# Altium schematic sheet MCP73831_BMS.SchDoc
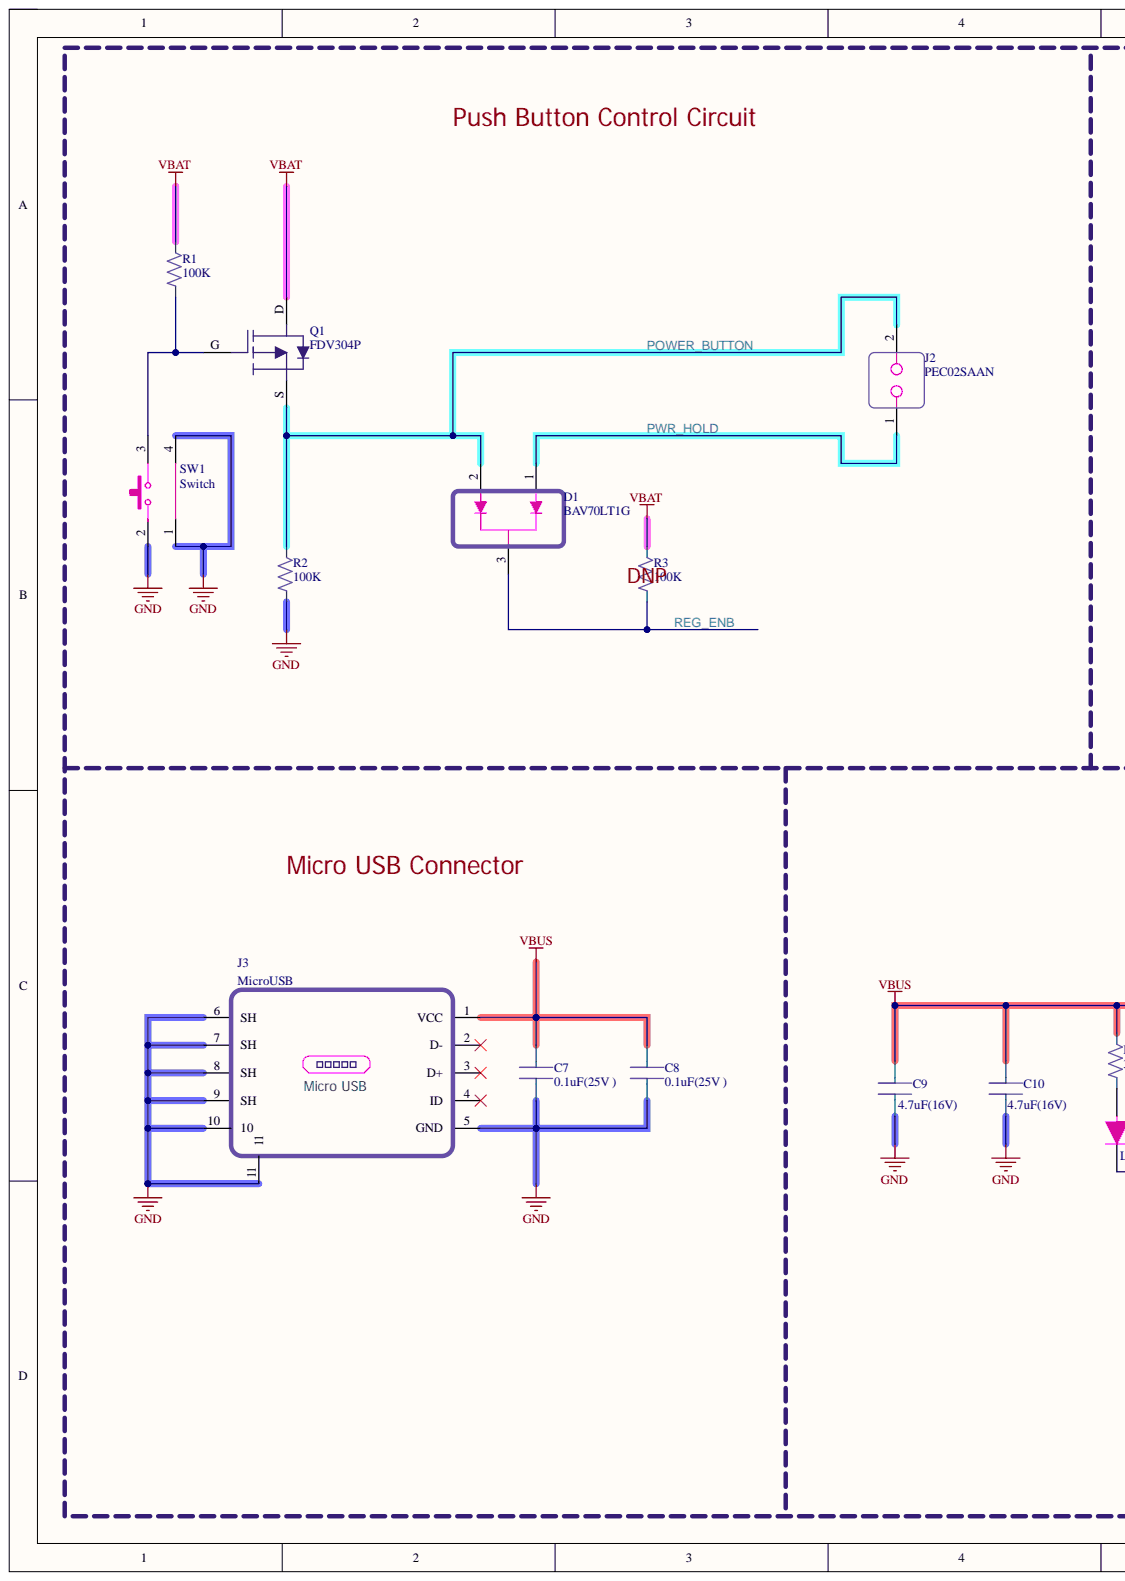
[diagram p0: MCP73831_BMS.SchDoc, left]
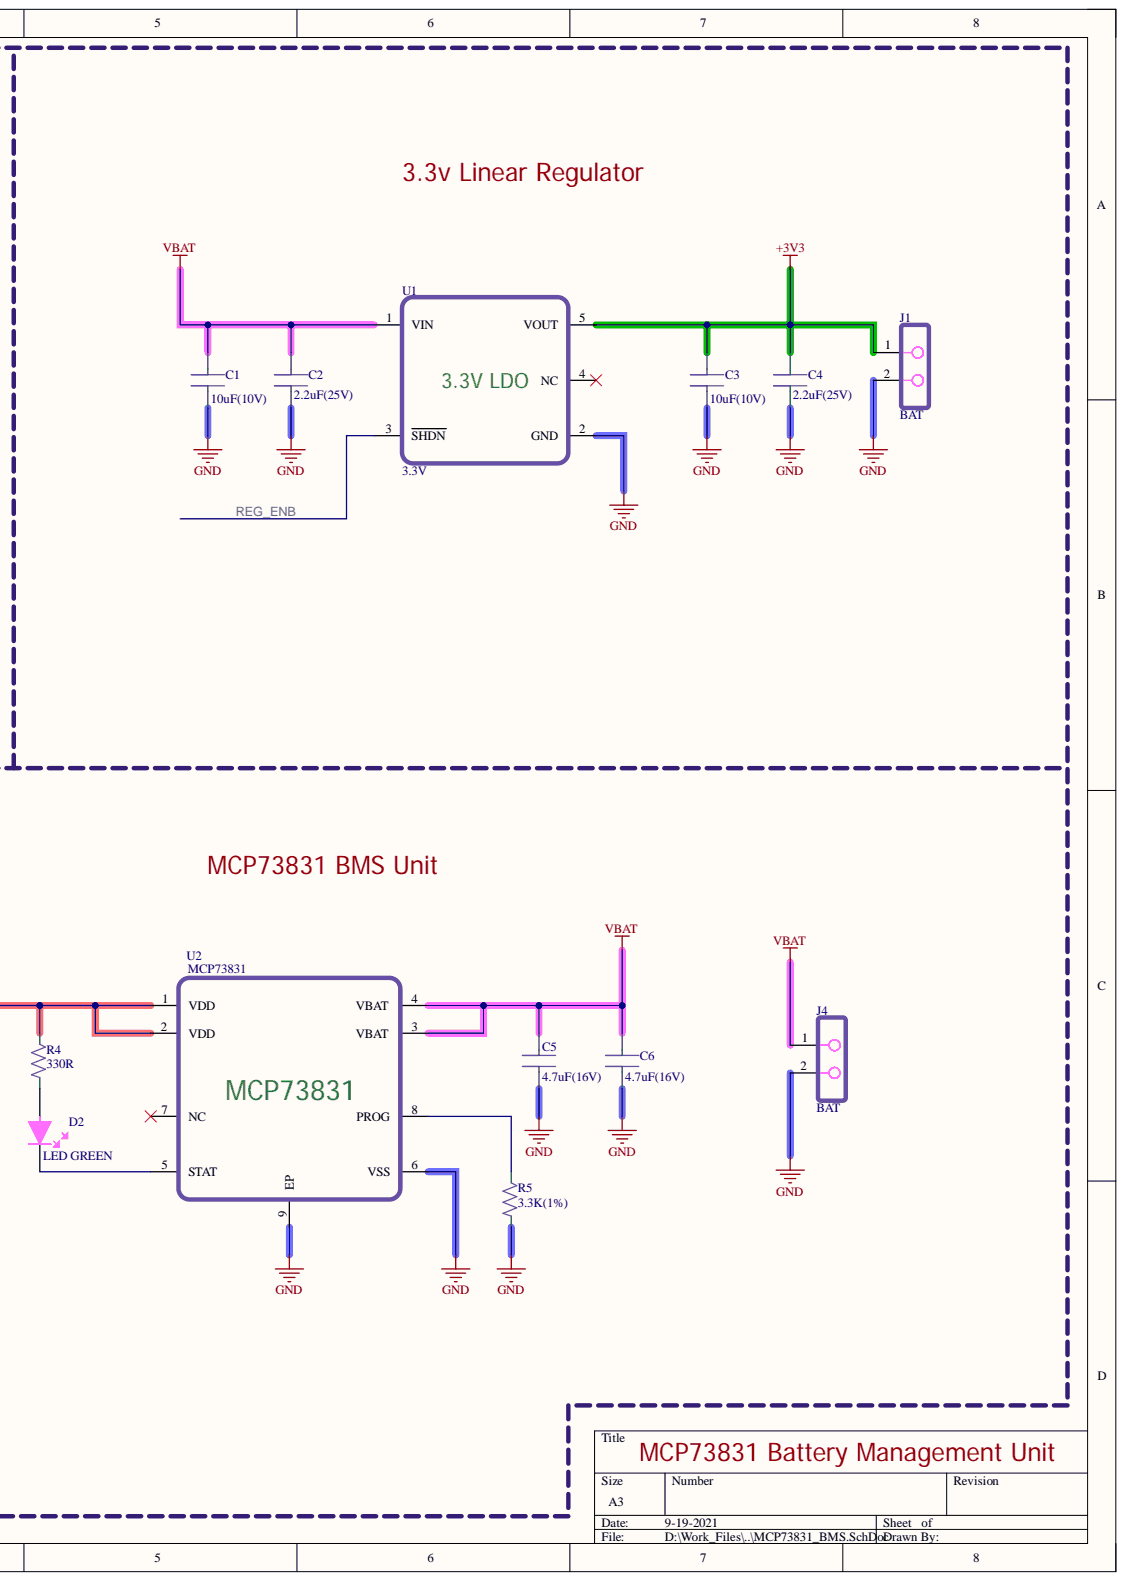
[diagram p1: MCP73831_BMS.SchDoc, right]

## Parts
C1  10uF(10V)  [CAPACITOR_0402]
C2  2.2uF(25V)  [CAPACITOR_0402]
C3  10uF(10V)  [CAPACITOR_0402]
C4  2.2uF(25V)  [CAPACITOR_0402]
C5  4.7uF(16V)  [CAPACITOR_0402]
C6  4.7uF(16V)  [CAPACITOR_0402]
C7  0.1uF(25V )  [CAPACITOR_0402]
C8  0.1uF(25V )  [CAPACITOR_0402]
C9  4.7uF(16V)  [CAPACITOR_0402]
C10  4.7uF(16V)  [CAPACITOR_0402]
D1  BAV70LT1G  [SOT-23_3LEAD - BAV70]
D2  LED GREEN  [0603_LED]
J1  BAT  [SM02B-SRSS-TB(LF)(SN)]
J2  PEC02SAAN  [P2.541X2HDR]
J3  MicroUSB  [Micro USB]
J4  BAT  [SM02B-SRSS-TB(LF)(SN)]
Q1  FDV304P  [SOT-23_3LEAD]
R1  100K  [RESISTOR_0402]
R2  100K  [RESISTOR_0402]
R3  100K  [RESISTOR_0402]
R4  330R  [RESISTOR_0402]
R5  3.3K(1%)  [RESISTOR_0402]
SW1  Switch  [right angle through hole switch]
U1  3.3V  [SOT23-5LEAD]
U2  MCP73831  [8VFDFN]

## Nets
+3V3: C3.2 C4.2 J1.1 U1.5(VOUT)
GND: C1.1 C2.1 C3.1 C4.1 C5.1 C6.1 C7.1 C8.1 C9.1 C10.1 J1.2 J3.5(GND) J3.6(SH) J3.7(SH) J3.8(SH) J3.9(SH) J3.10 J3.11 J4.2 R2.1 R5.1 SW1.1 SW1.2 SW1.4 U1.2(GND) U2.6(VSS) U2.9(EP)
VBAT: C1.2 C2.2 C5.2 C6.2 J4.1 Q1.D R1.2 R3.2 U1.1(VIN) U2.3(VBAT) U2.4(VBAT)
VBUS: C7.2 C8.2 C9.2 C10.2 J3.1(VCC) R4.2 U2.1(VDD) U2.2(VDD)
POWER_BUTTON: D1.2 J2.2 Q1.S R2.2
PWR_HOLD: D1.1 J2.1
REG_ENB: D1.3 R3.1 U1.3(SHDN)
NetD2_1: D2.1 R4.1
NetD2_2: D2.2 U2.5(STAT)
NetQ1_G: Q1.G R1.1 SW1.3
NetR5_2: R5.2 U2.8(PROG)

Not connected: J3 2(D-) 3(D+) 4(ID); U1 4(NC); U2 7(NC)

## Library data of the parts
C1 C3 = CL05A106MP8NUB8: 10µF ±20% 10V Ceramic Capacitor X5R 0402 (1005 Metric); Manufacturer: Samsung Electro-Mechanics; MaxTemp: 85°C; MinTemp: -55°C; Component Kind: Standard; ComponentLink1Description: SupplierURL; ComponentLink1URL: https://www.digikey.com/en/products/detail/samsung-electro-mechanics/CL05A106MP8NUB8/5961314; Library Name: Capacitors.IntLib; ManufacturerPartNumber: CL05A106MP8NUB8; PartStatus: Active; Price: 0.36; Supplier: Digikey; SupplierPartNumber: 1276-6830-1-ND
C2 C4 = GRT155R61E225KE13D: 2.2 µF ±10% 25V Ceramic Capacitor X5R 0402 (1005 Metric); Manufacturer: Murata Electronics; PartSatus: Active; MaxTemp: 85°C; MinTemp: -55°C; Component Kind: Standard; ComponentLink1Description: SupplierURL; ComponentLink1URL: https://www.digikey.com/en/products/detail/murata-electronics/GRT155R61E225KE13D/5416689; Library Name: Capacitors.IntLib; ManuafcturerPartNumber: GRT155R61E225KE13D; Price: 0.29; Supplier: Digikey; SupplierPartNumber: 490-12267-1-ND
C5 C6 C9 C10 = 0402YD475MAT2A: 4.7µF ±20% 16V Ceramic Capacitor X5R 0402 (1005 Metric); Manufacturer: AVX Corporation; MaxTemp: 85°C; MinTemp: -55°C; Component Kind: Standard; ComponentLink1Description: SupplierURL; ComponentLink1URL: https://www.digikey.com/en/products/detail/avx-corporation/0402YD475MAT2A/6221357; Library Name: Capacitors.IntLib; ManufacturerPartNumber: 0402YD475MAT2A; PartStatus: Active; Price: 0.29; Supplier: Digikey; SupplierPartNumber: 478-10788-1-ND
C7 C8 = GRM155R71E104KE14J: 0.1µF ±10% 25V Ceramic Capacitor X7R 0402 (1005 Metric); Manufacturer: Murata Electronics; MaxTemp: 125°C; MinTemp: -55°C; Component Kind: Standard; ComponentLink1Description: SupplierURL; ComponentLink1URL: https://www.digikey.com/en/products/detail/murata-electronics/GRM155R71E104KE14J/4905174; Library Name: Capacitors.IntLib; ManufacturerPartNumber: GRM155R71E104KE14J; PartStatus: Active; Price: 0.10; Supplier: Digikey; SupplierPartNumber: 490-14603-1-ND
D1 = BAV70LT1G: Diode Array 1 Pair Common Cathode Standard 200mA (DC) Surface Mount TO-236-3, SC-59, SOT-23-3; Manufacturer: ON Semiconductor; MaxTemp: 150°C; MinTemp: -55°C; Component Kind: Standard; ComponentLink1Description: SupplierURL; ComponentLink1URL: https://www.digikey.com/en/products/detail/on-semiconductor/BAV70LT1G/918324; Library Name: Diodes.SchLib; ManufacturerPartNumber: BAV70LT1G; PartStatus: Active; Price: 0.14; Supplier: Digikey; SupplierPartNumber: BAV70LT1GOSCT-ND
D2 = XZVG53W-8: Green 570nm LED Indication - Discrete 2.1V 0603 (1608 Metric); Max Temp: +85°C; Min Temp: -40°C; Component Kind: Standard; ComponentLink1Description: Supplier Link; ComponentLink1URL: https://www.digikey.com/en/products/detail/sunled/XZVG53W-8/4745971; Library Name: Diodes.IntLib; Manufacturer Part Number: XZVG53W-8; Part Status: Active; Price: 0.36; Supplier Part Number: 1497-1215-1-ND
J1 J4 = SM02B-SRSS-TB(LF)(SN): Connector Header Surface Mount, Right Angle 2 position 0.039" (1.00mm); Manufacturer: JST Sales America Inc.; MaxTemp: 85°C; MinTemp: -25°C; Component Kind: Standard; ComponentLink1Description: SupplierURL; ComponentLink1URL: https://www.digikey.com/en/products/detail/jst-sales-america-inc/SM02B-SRSS-TB-LF-SN/926708; Library Name: connectors.IntLib; ManufacturerPartNumber: SM02B-SRSS-TB(LF)(SN); PartStatus: Active; Price: 0.47; Supplier: Digikey; SupplierPartNumber: 455-1802-1-ND
J2 = PEC02SAAN: Connector Header Through Hole 2 position 0.100" (2.54mm); Max Temp: 125°C; Min Temp: -65°C; Component Kind: Standard; ComponentLink1Description: Supplier Link; ComponentLink1URL: https://www.digikey.com/en/products/detail/sullins-connector-solutions/PEC02SAAN/859155; Library Name: connectors.IntLib; Manyfacturer Part Number: PEC02SAAN; Part Status: Active; Price: 0.18; Supplier Part Number: S1012E-02-ND
J3 = 629105150521: USB - micro B USB 2.0 Receptacle Connector 5 Position Surface Mount, Right Angle; Through Hole; Manufacturer: Würth Elektronik; MaxTemp: 85°C; MinTemp: -40°C; Component Kind: Standard; ComponentLink1Description: SupplierURL; ComponentLink1URL: https://www.digikey.com/en/products/detail/würth-elektronik/629105150521/5047751; Library Name: Connectors.SchLib; ManufacturerPartNumber: 629105150521; PartStatus: Active; Price: 2.07; Supplier: Digikey; SupplierPartNumber: 732-5960-1-ND
Q1 = FDV304P: P-Channel 460mA (Ta) 350mW (Ta) Surface Mount SOT-23; Manufacturer: ON Semiconductor; MaxTemp: 150°C; MinTemp: -55°C; Component Kind: Standard; ComponentLink1Description: SupplierURL; ComponentLink1URL: c; ComponentLink2Description: MouserURL; ComponentLink2URL: https://www.mouser.in/ProductDetail/Microchip-Technology/MCP1812BT-033-OT?qs=byeeYqUIh0NTmNeIvl%2Fnbw%3D%3D; Library Name: Integrated Circuits.SchLib; ManufacturerPartNumber: FDV304P; PartStatus: Active; Price: 0.34; Supplier: Digikey; SupplierPartNumber: FDV304PCT-ND
R1-R3 = ERJ-2GEJ104X: 100 kOhms ±5% 0.1W, 1/10W Chip Resistor 0402 (1005 Metric) Automotive AEC-Q200 Thick Film; Manufacturer: Panasonic Electronic Components; MaxTemp: 155°C; MinTemp: -55°C; Component Kind: Standard; ComponentLink1Description: SupplierURL; ComponentLink1URL: https://www.digikey.com/en/products/detail/panasonic-electronic-components/ERJ-2GEJ104X/146731; Library Name: Resistors.IntLib; ManufacturerPartNumber: ERJ-2GEJ104X; PartStatus: Active; Price: 0.10; Supplier: Digikey; SupplierPartNumber: P100KJCT-ND
R4 = CRGCQ0603J330R: ±5% 0.1W, 1/10W Chip Resistor 0603 (1608 Metric) Automotive AEC-Q200, Moisture Resistant Thick Film; Manufacturer: TE Connectivity Passive Product; MaxTemp: 155°C; MinTemp: -55°C; Component Kind: Standard; ComponentLink1Description: SupplierURL; ComponentLink1URL: https://www.digikey.com/en/products/detail/te-connectivity-passive-product/CRGCQ0603J330R/8576690; Library Name: Resistors.IntLib; ManufacturerPartNumber: CRGCQ0603J330R; PartStatus: Active; Price: 0.10; Supplier: Digikey; SupplierPartNumber: A130088CT-ND
R5 = RK73H1ETTP3301F: 3.3 ±1% 0.1W, 1/10W Chip Resistor 0402 (1005 Metric) Automotive AEC-Q200, Moisture Resistant Thick Film; Manufacturer: KOA Speer Electronics, Inc.; MaxTemp: 155°C; MinTemp: -55°C; Component Kind: Standard; ComponentLink1Description: SupplierURL; ComponentLink1URL: https://www.digikey.com/en/products/detail/koa-speer-electronics-inc/RK73H1ETTP3301F/9844094; Library Name: Resistors.IntLib; ManufacturerPartNumber: RK73H1ETTP3301F; PartStatus: Active; Price: 0.10; Supplier: Digikey; SupplierPartNumber: 2019-RK73H1ETTP3301FCT-ND
SW1 = 1301.9502: Tactile Switch SPST-NO Side Actuated Through Hole, Right Angle; Manufacturer: Schurter Inc.; MaxTemp: 70°C; MinTemp: -20°C; Component Kind: Standard; ComponentLink1Description: SupplierURL; ComponentLink1URL: https://www.digikey.com/en/products/detail/schurter-inc/1301-9502/7602720; ComponentLink2Description: rydoLabs; ComponentLink2URL: https://www.rhydolabz.com/hardwares-switches-c-170_171/right-angle-tactile-push-button-switch-5mm-shaft-dip-p-2817.html; Library Name: connectors.IntLib; ManufacturerPartNumber: 1301.9502; PartStatus: Active; Price: 0.30; Supplier: Digikey; SupplierPartNumber: 486-3472-ND
U1 = MCP1812BT-033/OT: LDO Voltage Regulators Ultra Low Iq LDO; Manufacturer: Microchip Technology; MaxTemp: + 85 C; MinTemp: - 40 C; Component Kind: Standard; ComponentLink1Description: SupplierURL; ComponentLink1URL: https://www.mouser.in/ProductDetail/Microchip-Technology/MCP1812BT-033-OT?qs=byeeYqUIh0NTmNeIvl%2Fnbw%3D%3D; Library Name: Integrated Circuits.SchLib; ManuafcturerPartNumber: MCP1812BT-033/OT; PartStatus: Active; Price: 29.11(INR); Supplier: Mouser; SupplierPartNumber: 579-MCP1812BT-033/OT
U2 = MCP73831T-2DCI/MC: Charger IC Lithium Ion/Polymer 8-DFN (2x3); Manufacturer: Microchip Technology; MaxTemp: 85°C; MinTemp: -40°C; Component Kind: Standard; ComponentLink1Description: SupplierURL; ComponentLink1URL: https://www.digikey.in/en/products/detail/microchip-technology/MCP73831T-2DCI-MC/964304; Library Name: Integrated Circuits.SchLib; ManufacturerPartNumber: MCP73831T-2DCI/MC; PartStatus: Active; Price: 0.62; Supplier: Digikey; SupplierPartNumber: MCP73831T-2DCI/MCCT-ND
